# Altium schematic sheet DMP_SEM_MCU.SchDoc(DMP_SEM_MCU)
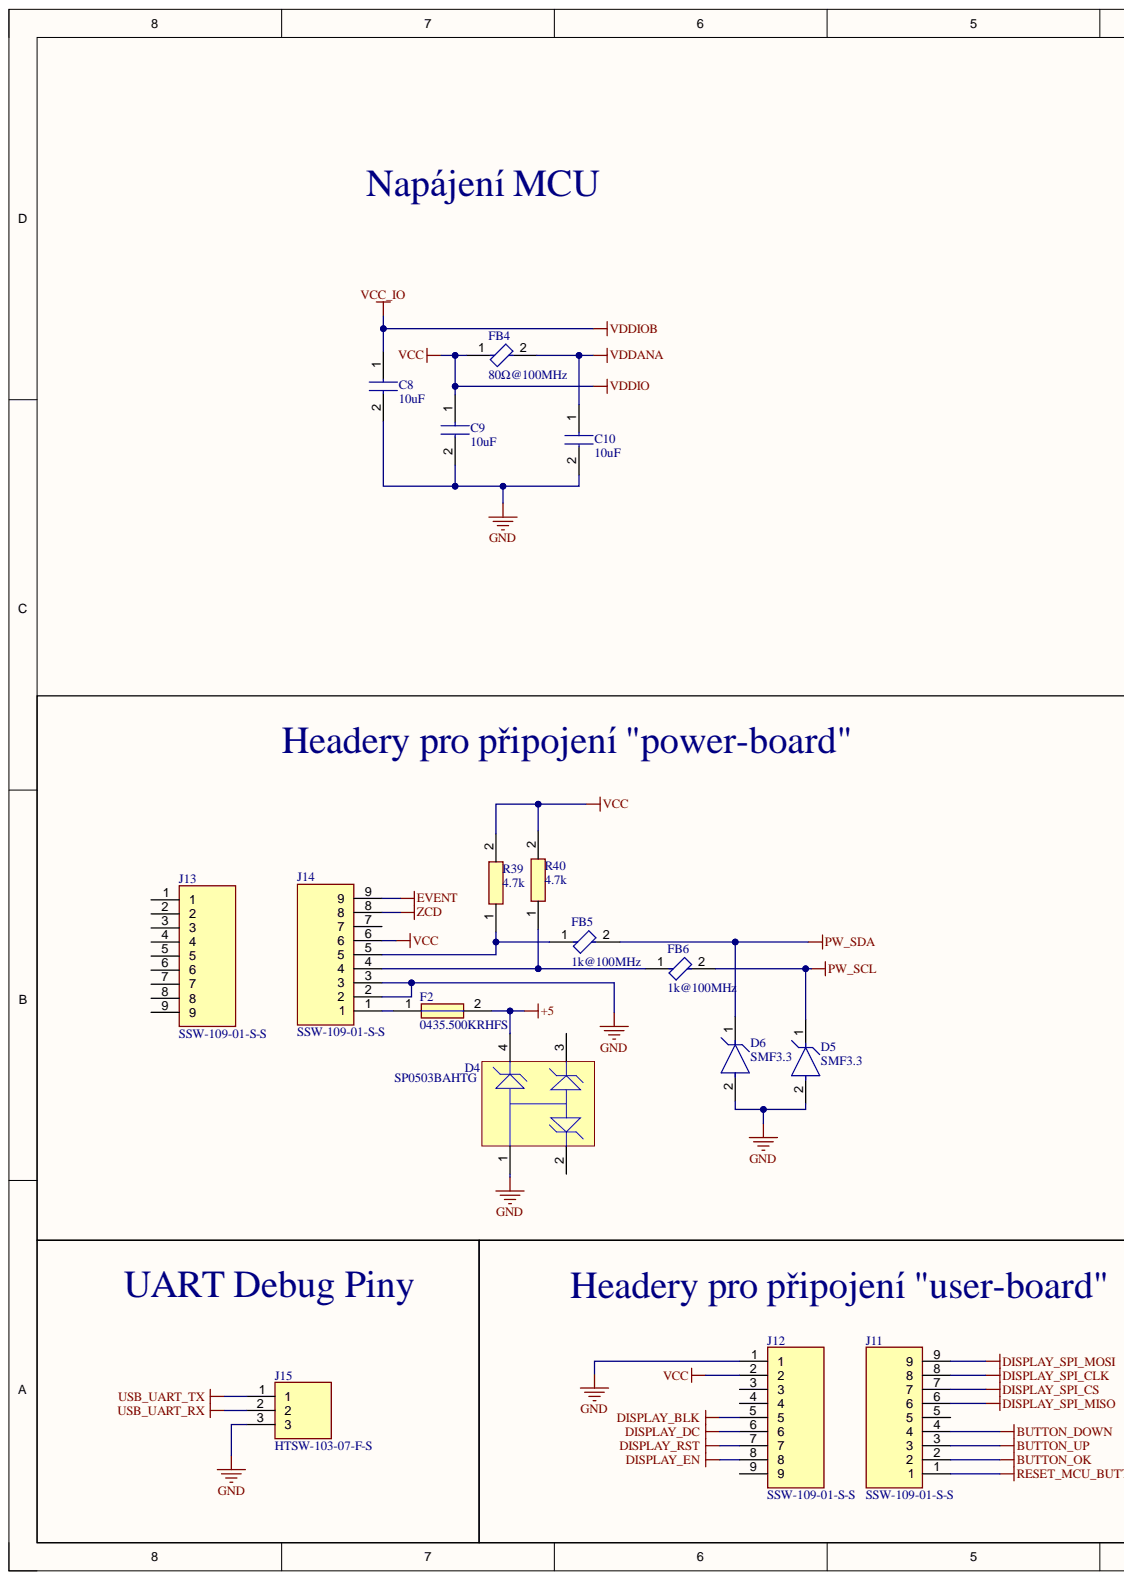
[diagram p0: DMP_SEM_MCU.SchDoc, left]
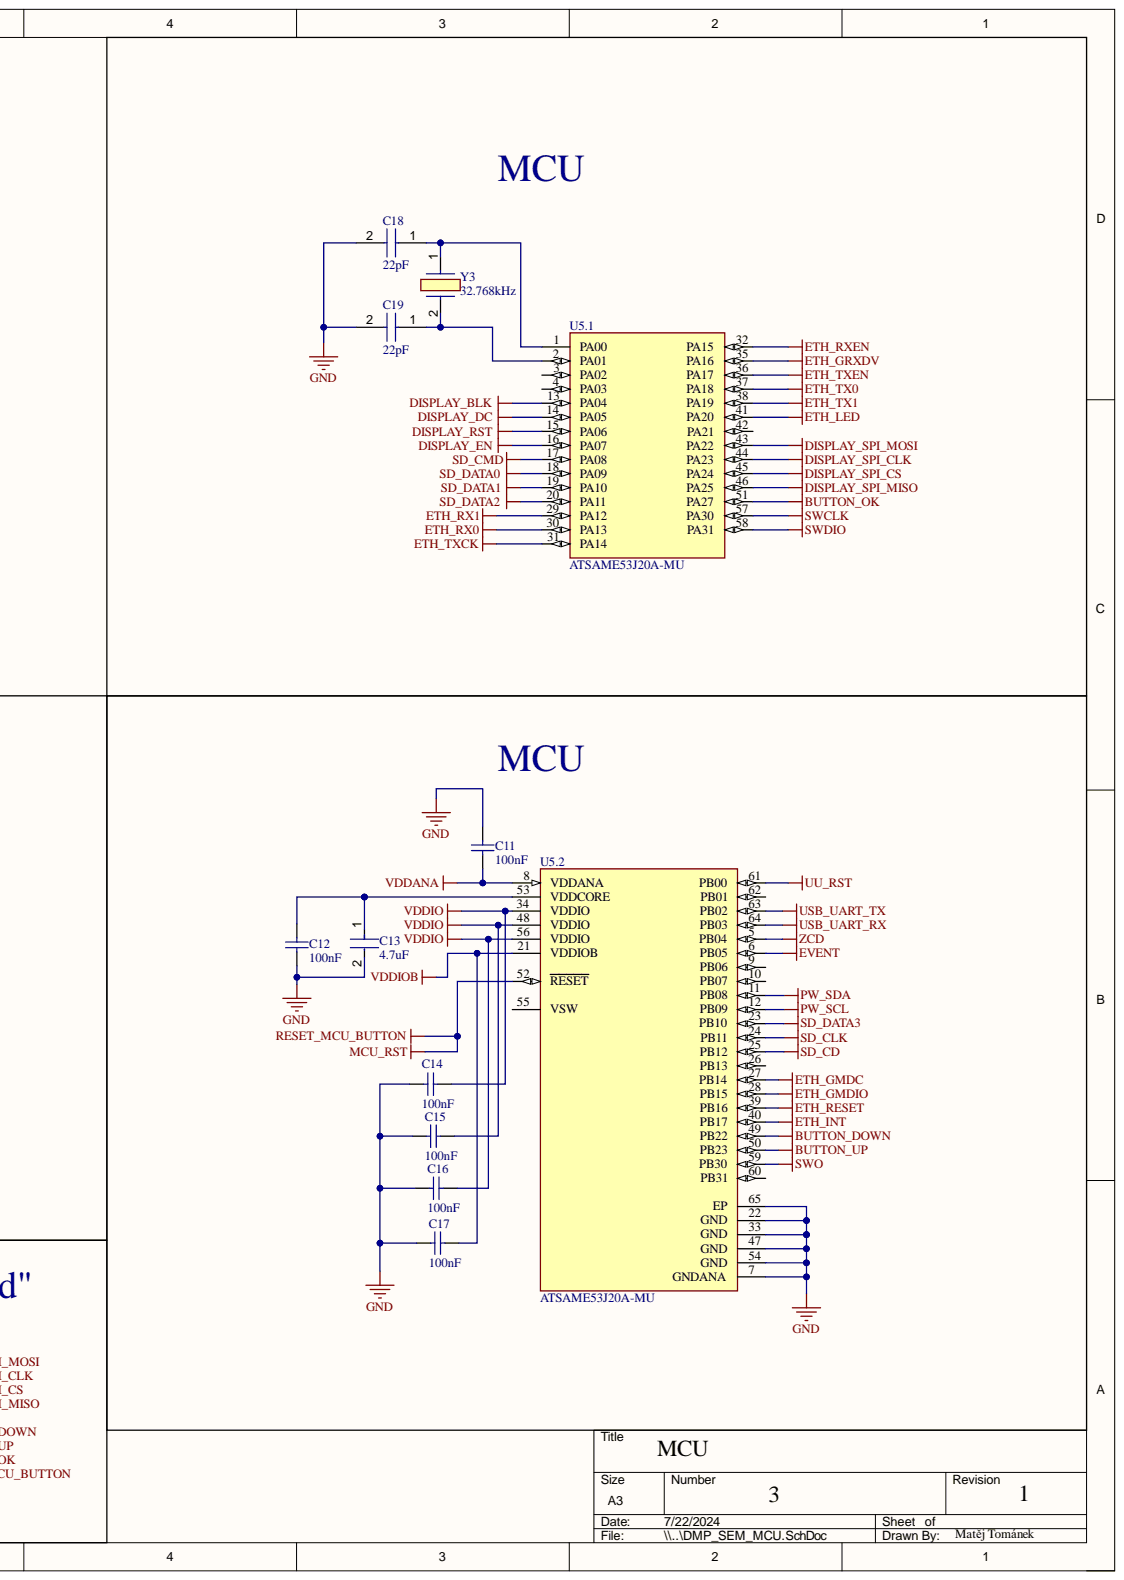
[diagram p1: DMP_SEM_MCU.SchDoc, right]

## Parts
C8  10uF  [CAPC1608X95N]
C9  10uF  [CAPC1608X95N]
C10  10uF  [CAPC1608X95N]
C11  100nF  [CAPC1050X55X23ML05T13]
C12  100nF  [CAPC1050X55X23ML05T13]
C13  4.7uF  [FP-CL828-IPC_A]
C14  100nF  [CAPC1050X55X23ML05T13]
C15  100nF  [CAPC1050X55X23ML05T13]
C16  100nF  [CAPC1050X55X23ML05T13]
C17  100nF  [CAPC1050X55X23ML05T13]
C18  22pF  [CAPC1005X55N]
C19  22pF  [CAPC1005X55N]
D4  SP0503BAHTG  [LFUS-SOT143-4_V]
D5  SMF3.3  [FP-SMF3_3-MFG]
D6  SMF3.3  [FP-SMF3_3-MFG]
F2  0435.500KRHFS  [FUSC1005X43N]
FB4  80Ω@100MHz  [FP-BLM15-0_05-IPC_A]
FB5  1k@100MHz  [FP-BLM15-0_05-IPC_B]
FB6  1k@100MHz  [FP-BLM15-0_05-IPC_B]
J11  SSW-109-01-S-S  [SAMTEC_SSW-109-01-S-S]
J12  SSW-109-01-S-S  [SAMTEC_SSW-109-01-S-S]
J13  SSW-109-01-S-S  [SAMTEC_SSW-109-01-S-S]
J14  SSW-109-01-S-S  [SAMTEC_SSW-109-01-S-S]
J15  HTSW-103-07-F-S  [HDRV3W64P0X254_1X3_762X248X838P]
R39  4.7k  [RESC1608X55N]
R40  4.7k  [RESC1608X55N]
U5  ATSAME53J20A-MU  [FP-PA-IPC_A]  (parts .1,.2)
Y3  32.768kHz  [Q13FC1350000400]

## Nets
+5: D4.4 F2.2
BUTTON_DOWN: J11.4 U5.49(PB22)
BUTTON_OK: J11.2 U5.51(PA27)
BUTTON_UP: J11.3 U5.50(PB23)
DISPLAY_BLK: J12.5 U5.13(PA04)
DISPLAY_DC: J12.6 U5.14(PA05)
DISPLAY_EN: J12.8 U5.16(PA07)
DISPLAY_RST: J12.7 U5.15(PA06)
DISPLAY_SPI_CLK: J11.8 U5.44(PA23)
DISPLAY_SPI_CS: J11.7 U5.45(PA24)
DISPLAY_SPI_MISO: J11.6 U5.46(PA25)
DISPLAY_SPI_MOSI: J11.9 U5.43(PA22)
ETH_GMDC: U5.27(PB14)
ETH_GMDIO: U5.28(PB15)
ETH_GRXDV: U5.35(PA16)
ETH_INT: U5.40(PB17)
ETH_LED: U5.41(PA20)
ETH_RESET: U5.39(PB16)
ETH_RX0: U5.30(PA13)
ETH_RX1: U5.29(PA12)
ETH_RXEN: U5.32(PA15)
ETH_TX0: U5.37(PA18)
ETH_TX1: U5.38(PA19)
ETH_TXCK: U5.31(PA14)
ETH_TXEN: U5.36(PA17)
EVENT: J14.9 U5.6(PB05)
GND: C8.2 C9.2 C10.2 C11.2 C12.2 C13.2 C14.1 C15.1 C16.1 C17.1 C18.2 C19.2 D4.1 D5.2 D6.2 J12.1 J14.2 J14.3 J15.3 U5.7(GNDANA) U5.22(GND) U5.33(GND) U5.47(GND) U5.54(GND) U5.65(EP)
MCU_RST: J11.1 U5.52(RESET)
PW_SCL: D5.1 FB6.2 U5.12(PB09)
PW_SDA: D6.1 FB5.2 U5.11(PB08)
SD_CD: U5.25(PB12)
SD_CLK: U5.24(PB11)
SD_CMD: U5.17(PA08)
SD_DATA0: U5.18(PA09)
SD_DATA1: U5.19(PA10)
SD_DATA2: U5.20(PA11)
SD_DATA3: U5.23(PB10)
SWCLK: U5.57(PA30)
SWDIO: U5.58(PA31)
SWO: U5.59(PB30)
USB_UART_RX: J15.2 U5.64(PB03)
USB_UART_TX: J15.1 U5.63(PB02)
UU_RST: U5.61(PB00)
VCC: C9.1 C14.2 C15.2 C16.2 FB4.1 J12.2 J14.6 R39.2 R40.2 U5.34(VDDIO) U5.48(VDDIO) U5.56(VDDIO)
VCC_IO: C8.1 C17.2 U5.21(VDDIOB)
VDDANA: C10.1 C11.1 FB4.2 U5.8(VDDANA)
ZCD: J14.8 U5.5(PB04)
NetC12_1: C12.1 C13.1 U5.53(VDDCORE)
NetC18_1: C18.1 U5.1(PA00) Y3.1
NetC19_1: C19.1 U5.2(PA01) Y3.2
NetF2_1: F2.1 J14.1
NetFB5_1: FB5.1 J14.5 R39.1
NetFB6_1: FB6.1 J14.4 R40.1

Not connected: D4 2 3; J11 5; J12 3 4 9; J13 1 2 3 4 5 6 7 8 9; J14 7; U5 3(PA02) 4(PA03) 9(PB06) 10(PB07) 26(PB13) 42(PA21) 55(VSW) 60(PB31) 62(PB01)

## Library data of the parts
C8-C10 = CL10A106KO8NQNC: Capacitor 10uF CL10A106KO8NQNC; Manufacturer_Name: SAMSUNG; Arrow Part Number: CL10A106KO8NQNC; Arrow Price/Stock: https://www.arrow.com/en/products/cl10a106ko8nqnc/samsung-electro-mechanics; Component Kind: Standard; ComponentLink1Description: shop; ComponentLink1URL: https://cz.mouser.com/ProductDetail/Samsung-Electro-Mechanics/CL10A106KO8NQNC?qs=xZ%2FP%252Ba9zWqY%252BA5vKSHboXg%3D%3D; Datasheet Link: https://product.samsungsem.com/mlcc/CL10A106KO8NQN.do; Height: 0.95mm; Library Name: SamacSys.SchLib; Manufacturer_Part_Number: CL10A106KO8NQNC
C11 C12 C14-C17 = CMP-2000-05439-1: Chip Capacitor, 100 nF, +/- 10%, 16 V, -55 to 125 degC, 0402 (1005 Metric), RoHS, Tape and ReelCL05B104KO5NNNC; Manufacturer: Samsung Electro-Mechanics; Capacitance: 100000pF; Dielectric: X7R; Rated Voltage: 16V; Case Size/Dimension: 0402; Component Kind: Standard; Datasheet URL: https://datasheet.ciiva.com/29198/458718-da-01-en-vs-kondensator-0402-29198423.pdf; Datasheet Version: Nov-10; Library Name: Altium Content Vault; Manufacturer URL: http://www.samsungsem.com/global/index.jsp; Tolerance (%): ±10%
C13 = CMP-13271-001251-1: Cap Ceramic 4.7uF 10V X5R ±10% SMD 0402 +85°C Paper T/R   CL05A475KP5NRNC; Capacitance: 4.7uF; Case Code (Imperial): 0402; Case Code (Metric): 1005; Case/Package: 0402; Component Kind: Standard; Depth: 0.5mm; Dielectric: X5R; Height: 0.5mm; Lead Free: Lead Free; Length: 1mm; Library Name: Altium Content Vault; Material: Ceramic; Max Operating Temperature: 85°C; Min Operating Temperature: -55°C; Mount: Surface Mount; Package Quantity: 1; Packaging: Tape and Reel; Radiation Hardening: No; Thickness: 0.022inch; Tolerance: 10%; Voltage Rating: 10V; Width: 0.02inch
C18 C19 = CC0402JRNPO9BN220: Capacitor CC0402JRNPO9BN220; Manufacturer_Name: YAGEO; Arrow Part Number: CC0402JRNPO9BN220; Arrow Price/Stock: https://www.arrow.com/en/products/cc0402jrnpo9bn220/yageo?region=nac; Component Kind: Standard; ComponentLink1Description: shop; ComponentLink1URL: https://cz.mouser.com/ProductDetail/YAGEO/CC0402JRNPO9BN220?qs=AgBp2OyFlx9i3sVl6vpnFQ%3D%3D; Datasheet Link: https://www.yageo.com/upload/media/product/productsearch/datasheet/mlcc/UPY-GP_NP0_16V-to-50V_18.pdf; Height: 0.55mm; Library Name: SamacSys.SchLib; Manufacturer_Part_Number: CC0402JRNPO9BN220; Mouser Part Number: 603-CC402JRNPO9BN220; Mouser Price/Stock: https://www.mouser.co.uk/ProductDetail/YAGEO/CC0402JRNPO9BN220?qs=AgBp2OyFlx9i3sVl6vpnFQ%3D%3D
D4 = CMP-2000-05191-1: TVS Diode Array, 30 pF, 30 KV, -40 to 85 degC, 4-Pin SOT143, RoHS, Tape and Reel; Manufacturer: Littelfuse; Capacitance Value: 30pF; Clamping Voltage: 8V; Configuration: Triple; Direction Type: Uni-Directional; Leakage Current: 0.1uA; Number of Elements: 3; Product Depth (mm): 1.4mm; Product Height (mm): 1.05mm; Product Length (mm): 3.04mm; Rad Hardened: No; Reverse Stand-off Voltage: 5.5(Min)V; Working Voltage: 5.5V; Working Voltage (Max): 5.5V(Min); Clamping Voltage (Max): 8V; Component Kind: Standard; Datasheet URL: https://datasheet.ciiva.com/15143/getdatasheetpartid-116020-15143550.pdf; Datasheet Version: Dec-13; Leakage Current (Max): 0.1uA; Library Name: Altium Content Vault; Manufacturer URL: http://www.littelfuse.com/; Mounting: SurfaceMount; Mounting Style: SurfaceMount; Operating Temp Range: -40Cto85C; Operating Temperature Classification: Industrial; Operating Temperature Max Deg. C: 85C; Operating Temperature Min Deg. C: -40C; Package / Case: SOT-143; Package Type: SOT-143; Packaging: TapeandReel; Pin Count: 3+Tab; Polarity: Uni-Directional; Suppressor Type: TVS
D5 D6 = CMP-08607-000053-1: TVS DIODE 3.3V 6.8V SOD123FL; Manufacturer: Littelfuse; Breakdown Voltage: 3.4V; Direction: Unidirectional; Max Junction Temp: +150°C; Number Of Channels: 1; Peak Pulse Current: 30A; Peak Pulse Power: 200W; Standoff Height: 0mm; Automotive: No; Category: Diode; Clamping Voltage: 6.8V; Component Kind: Standard; ComponentLink2Description: Datasheet; ComponentLink2URL: https://www.littelfuse.com/~/media/electronics/datasheets/tvs_diodes/littelfuse_tvs_diode_smf3_3_datasheet.pdf.pdf; Device Class L1: Circuit Protection; Device Class L2: TVS Diodes; Device Class L3: unset; Digikey Description: TVS DIODE 3.3V 6.8V SOD123FL; Height: 1.08mm; Lead Free: Yes; Library Name: Altium Content Vault; Manufacturer Part Number: SMF3.3; Mouser Description: Diode TVS Single Uni-Dir 3.3V 1.2KW 2-Pin SOD-123FL T/R; Octopart: https://octopart.com/smf3.3-littelfuse-75449841; Package: 0; Reverse Standoff Voltage: 3.3V; RoHS: TRUE; Temperature: -55°C to +150°C; Temperature Range High: +150°C; Temperature Range Low: -55°C
F2 = 0435.500KRHFS: Fuse; Manufacturer_Name: LITTELFUSE; Component Kind: Standard; Datasheet Link: https://4donline.ihs.com/images/VipMasterIC/IC/LFSI/LFSI-S-A0001437699/LFSI-S-A0001437699-1.pdf?hkey=EF798316E3902B6ED9A73243A3159BB0; Height: 0.432mm; Library Name: SamacSys.SchLib; Manufacturer_Part_Number: 0435.500KRHFS
FB4 = CMP-0686-00284-4: Chip Ferrite Bead, 0402, 80Ω @ 100MHz, 0.07Ω, 25%, 1.5A        BLM15PD800SN1D; DC Resistance (DCR): 70mR; Impedance: 80R; Number of Circuits: 1; Case Code (Imperial): 0402; Case Code (Metric): 1005; Case/Package: 0402; Component Kind: Standard; Current Rating: 1.5A; DC Resistance-Max: 70mR; Depth: 0.5mm; Height: 0.5mm; Lead Free: Lead Free; Length: 1mm; Library Name: Altium Content Vault; Material: Ferrite; Max Current Rating: 1.5A; Max DC Current: 1.5A; Max Operating Temperature: 125°C; Min Operating Temperature: -55°C; Number of Lines: 1; Number of Pins: 2; Package Quantity: 1; Packaging: Tape and Reel; REACH SVHC: No SVHC; RoHS Compliant: Yes; Series: BLM15PD; Termination: SMD/SMT; Test Frequency: 100MHz; Tolerance: 25%; Width: 0.5mm
FB5 = CMP-0686-00192-4: Chip Ferrite Bead, 0402, 1000Ω @ 100MHz, 0.9Ω, 25%, 200mA                              BLM15BD102SN1D; DC Resistance (DCR): 900mR; Impedance: 1kR; Material: Steel; Number of Circuits: 1; Shielding: Unshielded; Case Code (Imperial): 0402; Case Code (Metric): 1005; Case/Package: 0402; Component Kind: Standard; Core Material: Ferrite; Current Rating: 200mA; DC Resistance-Max: 900mR; Depth: 0.5mm; Frequency: 100MHz; Height: 0.5mm; Lead Free: Lead Free; Length: 1mm; Library Name: Altium Content Vault; Max Current Rating: 200mA; Max DC Current: 200mA; Max Operating Temperature: 125°C; Min Operating Temperature: -55°C; Number of Lines: 1; Number of Pins: 2; Package Quantity: 1; Packaging: Tape and Reel; Radiation Hardening: No; REACH SVHC: No SVHC; Resistance: 900mR; Series: BLM15B; Termination: Flat; Test Frequency: 100MHz; Tolerance: 25%; Width: 0.5mm
FB6 = CMP-0686-00192-4: Chip Ferrite Bead, 0402, 1000Ω @ 100MHz, 0.9Ω, 25%, 200mA                                  BLM15BD102SN1D; DC Resistance (DCR): 900mR; Impedance: 1kR; Material: Steel; Number of Circuits: 1; Shielding: Unshielded; Case Code (Imperial): 0402; Case Code (Metric): 1005; Case/Package: 0402; Component Kind: Standard; Core Material: Ferrite; Current Rating: 200mA; DC Resistance-Max: 900mR; Depth: 0.5mm; Frequency: 100MHz; Height: 0.5mm; Lead Free: Lead Free; Length: 1mm; Library Name: Altium Content Vault; Max Current Rating: 200mA; Max DC Current: 200mA; Max Operating Temperature: 125°C; Min Operating Temperature: -55°C; Number of Lines: 1; Number of Pins: 2; Package Quantity: 1; Packaging: Tape and Reel; Radiation Hardening: No; REACH SVHC: No SVHC; Resistance: 900mR; Series: BLM15B; Termination: Flat; Test Frequency: 100MHz; Tolerance: 25%; Width: 0.5mm
J11-J14 = SSW-109-01-S-S: Connector; Manufacturer_Name: SAMTEC; Arrow Part Number: SSW-109-01-S-S; Arrow Price/Stock: https://www.arrow.com/en/products/ssw-109-01-s-s/samtec; Component Kind: Standard; Datasheet Link: http://suddendocs.samtec.com/prints/ssw-1xx-xx-xxx-x-xx-xxx-xx-mkt.pdf; Manufacturer_Part_Number: SSW-109-01-S-S; Mouser Part Number: 200-SSW10901SS; Mouser Price/Stock: https://www.mouser.co.uk/ProductDetail/Samtec/SSW-109-01-S-S?qs=92ilVni64gyiynRZGJ4lIQ%3D%3D
J15 = HTSW-103-07-F-S: Connector; Manufacturer_Name: SAMTEC; Arrow Part Number: HTSW-103-07-F-S; Arrow Price/Stock: https://www.arrow.com/en/products/htsw-103-07-f-s/samtec?region=nac; Component Kind: Standard; Datasheet Link: http://suddendocs.samtec.com/catalog_english/htsw_th.pdf; Height: 8.38mm; Manufacturer_Part_Number: HTSW-103-07-F-S; Mouser Part Number: 200-HTSW10307FS; Mouser Price/Stock: https://www.mouser.co.uk/ProductDetail/Samtec/HTSW-103-07-F-S?qs=0lQeLiL1qyYWvFAnBMcXdA%3D%3D
R39 R40 = ERJ-3EKF4701V: Resistor ERJ-3EKF4701V; Manufacturer_Name: Panasonic; Arrow Part Number: ERJ-3EKF4701V; Arrow Price/Stock: https://www.arrow.com/en/products/erj-3ekf4701v/panasonic?region=nac; Component Kind: Standard; Datasheet Link: http://industrial.panasonic.com/cdbs/www-data/pdf/RDA0000/AOA0000C86.pdf; Height: 0.55mm; Manufacturer_Part_Number: ERJ-3EKF4701V; Mouser Part Number: 667-ERJ-3EKF4701V; Mouser Price/Stock: https://www.mouser.co.uk/ProductDetail/Panasonic/ERJ-3EKF4701V?qs=MVjVSMjNRMouVvwnqrpO2w%3D%3D
U5 = CMP-06276-000633-1: IC MCU 32BIT 1MB FLASH 64VQFN; Core Architecture: ARM; Frequency: 120MHz; Interface: I2C; Number of I/Os: 51; Oscillator Type: Internal; Peripherals: Brown-out Detect/Reset; Case/Package: VQFN; Component Kind: Standard; Library Name: Altium Content Vault; Max Operating Temperature: 85°C; Memory Size: 1MB; Memory Type: FLASH; Min Operating Temperature: -40°C; Packaging: Tray
Y3 = Q13FC1350000400: Crystal or Oscillator Q13FC1350000400; Manufacturer_Name: Seiko Epson Corporation; Component Kind: Standard; ComponentLink1Description: shop; ComponentLink1URL: https://cz.mouser.com/ProductDetail/Epson-Timing/FC-135-32.7680KA-A5?qs=f9yNj16SXrJ7J65t%252BHv0JQ%3D%3D; Datasheet Link: https://datasheet.lcsc.com/szlcsc/1901081604_Seiko-Epson-Q13FC1350000400_C32346.pdf; Height: 0.9mm; Library Name: SamacSys.SchLib; Manufacturer_Part_Number: Q13FC1350000400
